# Altium schematic sheet Solenoid Interface.SchDoc("Solenoid Interface")
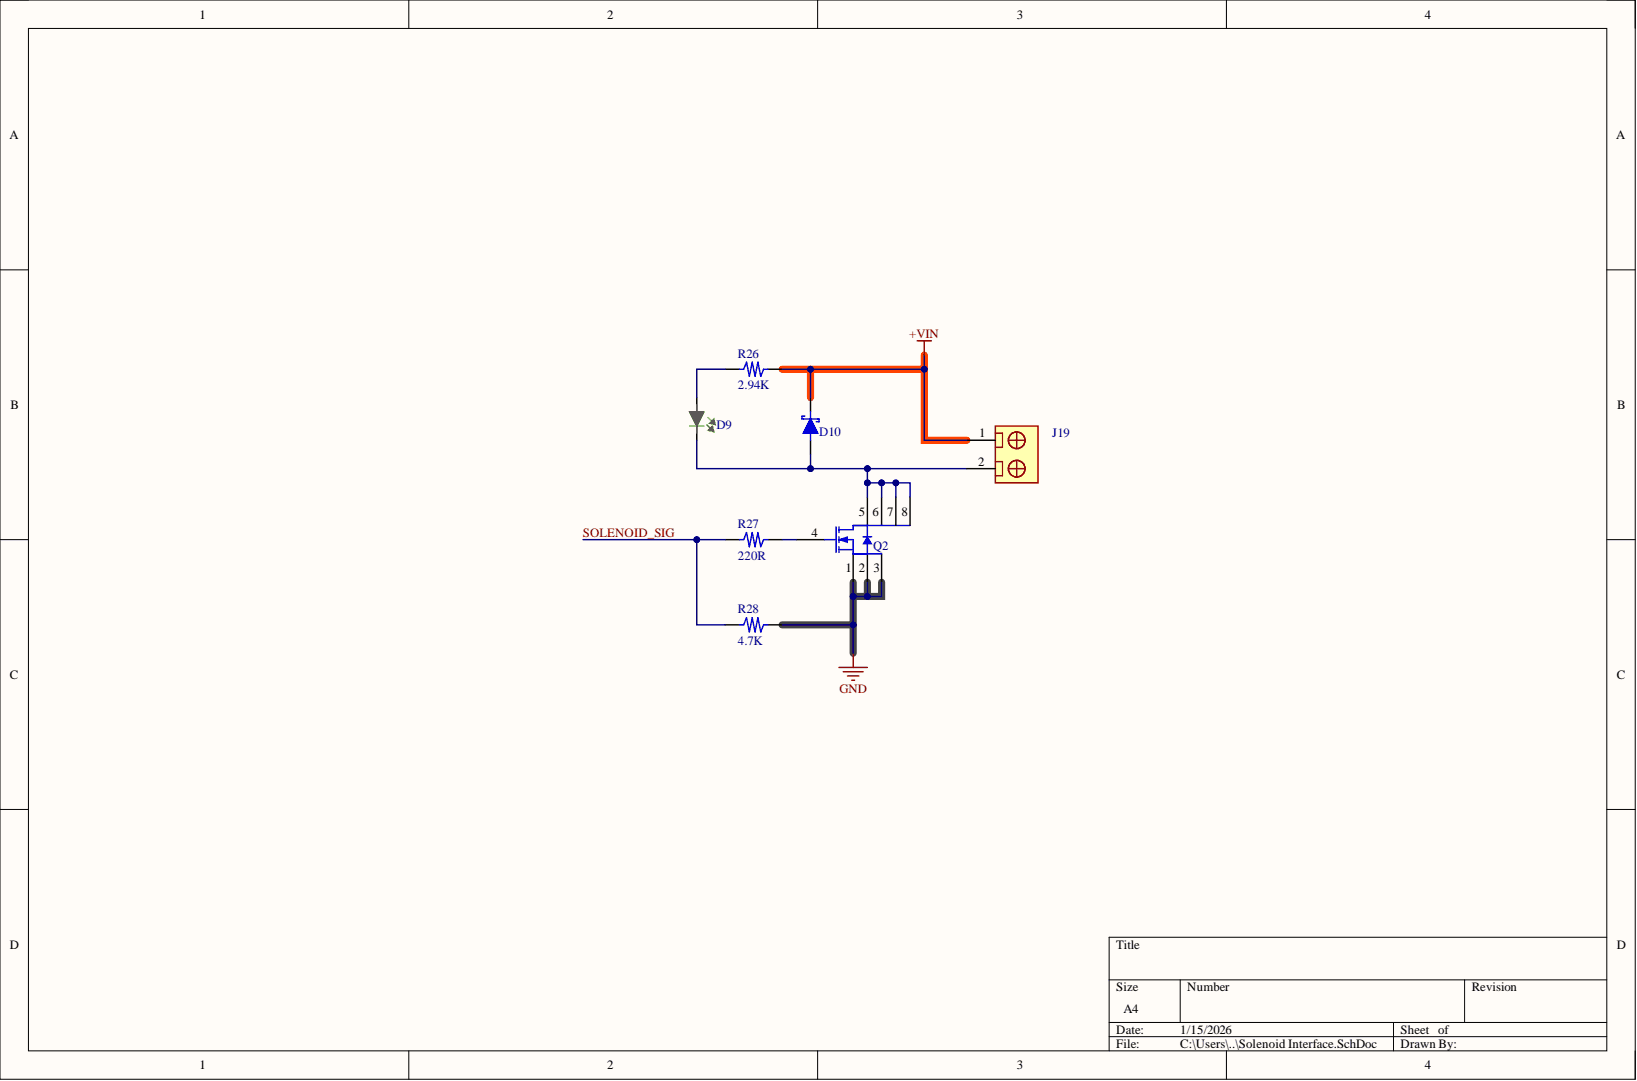
[diagram p0: Solenoid Interface.SchDoc]

## Parts
D9  LG R971-KN-1-0-20-R18  [LED0805]  (value not drawn)
D10  B340AE-13  [SMA(DO-214AC)]  (value not drawn)
J19  1935161  [1935161]  (value not drawn)
Q2  DMT6016LSS-13  [SO-8]  (value not drawn)
R26  value 2.94K  [RES0603]  (hidden comment: RC0603FR-072K94L)
R27  value 220R  [RES0603]  (hidden comment: RC0603FR-07220RL)
R28  value 4.7K  [RES0603]  (hidden comment: RC0603FR-074K7L)

## Nets
+VIN: D10.K J19.1 R26.1
GND: Q2.1 Q2.2 Q2.3 R28.2
SOLENOID_SIG: R27.2 R28.1
NetD9_A: D9.A R26.2
NetD9_K: D9.K D10.A J19.2 Q2.5 Q2.6 Q2.7 Q2.8
NetQ2_4: Q2.4 R27.1

## Library data of the parts
D9 = LG R971-KN-1-0-20-R18: Green 570nm LED Indication - Discrete 2.2V 0805 (2012 Metric); Manufacturer: ams-OSRAM USA INC.; Component Kind: Standard; ComponentLink1Description: Datasheet; ComponentLink1URL: https://look.ams-osram.com/m/2da6f2ceeebf8fac/original/LG-R971.pdf; ComponentLink2Description: DigiKey Link; ComponentLink2URL: https://www.digikey.com/en/products/detail/ams-osram-usa-inc/LG-R971-KN-1-0-20-R18/1227925?s=N4IgTCBcDaIDIHEAEAlAnAdgIwFoDSAcjrgAw5hkpYAcIAugL5A; ComponentLink3Description: LCSC Link; ComponentLink3URL: https://lcsc.com/product-detail/LED-Indication-Discrete_OSRAM-LG-R971-KN-1-0-20-R18_C2899888.html?s_z=n_LG%2520R971-KN-1-0-20-R18; DigiKey PN: 475-LGR971-KN-1CT-ND; LCSC PN: C2899888; Library Name: 05-Optoelectronics.IntLib; MPN: LG R971-KN-1-0-20-R18; Package: 0805
D10 = B340AE-13: DIODE SCHOTTKY 40V 3A SMA; Manufacturer: Diodes Incorporated; Component Kind: Standard; ComponentLink1Description: Datasheet; ComponentLink1URL: https://www.diodes.com/assets/Datasheets/B320AE/B340AE.pdf; ComponentLink2Description: DigiKey Link; ComponentLink2URL: https://www.digikey.com/en/products/detail/diodes-incorporated/B340AE-13/7352831; ComponentLink3Description: LCSC Link; ComponentLink3URL: https://lcsc.com/product-detail/Schottky-Diodes_Diodes-Incorporated-B340AE-13_C168859.html?s_z=n_B340AE-13; DigiKey PN: B340AE-13DICT-ND; LCSC PN: C168859; Library Name: 02-Diodes.IntLib; MPN: B340AE-13; Package: SMA(DO-214AC)
J19 = 1935161: TB 5.00mm 2POS TH 18A, 300V, 12-26 AWG Wire; Manufacturer: Phoenix Contact; Component Kind: Standard; ComponentLink1Description: Datasheet; ComponentLink1URL: https://mm.digikey.com/Volume0/opasdata/d220001/medias/docus/909/1935161.pdf; ComponentLink2Description: DigiKey Link; ComponentLink2URL: https://www.digikey.com/en/products/detail/phoenix-contact/1935161/568614; ComponentLink3Description: LCSC Link; ComponentLink3URL: https://www.lcsc.com/product-detail/C3819953.html?s_z=n_1935161; DigiKey PN: 277-1667-ND; LCSC PN: C3819953; Library Name: 11-Connectors_5.00mm.IntLib; MPN: 1935161; Series: COMBICON PT
Q2 = DMT6016LSS-13: MOSFET N-CH 60V 9.2A SO-8; Manufacturer: Diodes Incorporated; Component Kind: Standard; ComponentLink1Description: Datasheet; ComponentLink1URL: https://www.diodes.com/assets/Datasheets/DMT6016LSS.pdf; ComponentLink2Description: DigiKey Link; ComponentLink2URL: https://www.digikey.com/en/products/detail/diodes-incorporated/DMT6016LSS-13/4967042; ComponentLink3Description: LCSC Link; ComponentLink3URL: https://www.lcsc.com/product-detail/C151586.html?s_z=n_DMT6016LSS-13; DigiKey PN: DMT6016LSS-13DICT-ND; LCSC PN: C151586; Library Name: 03-Transistors_MOSFETS.IntLib; MPN: DMT6016LSS-13; Package: SO-8
R26 = RC0603FR-072K94L: 2.94 kOhms ±1% 0.1W, 1/10W Chip Resistor 0603 (1608 Metric) Moisture Resistant Thick Film; Manufacturer: YAGEO; Component Kind: Standard; ComponentLink1Description: Datasheet; ComponentLink1URL: https://www.yageo.com/upload/media/product/products/datasheet/rchip/PYu-RC_Group_51_RoHS_L_12.pdf; ComponentLink2Description: DigiKey Link; ComponentLink2URL: https://www.digikey.com/en/products/detail/yageo/RC0603FR-072K94L/727037?s=N4IgTCBcDaIE4GMAMA2JBmAZnAtEg7GANYCcALADYgC6AvkA; ComponentLink3Description: LCSC Link; ComponentLink3URL: https://lcsc.com/product-detail/Chip-Resistor-Surface-Mount_YAGEO-RC0603FR-072K94L_C137748.html?s_z=n_RC0603FR-072K94L; DigiKey PN: 311-2.94KHRCT-ND; LCSC PN: C137748; Library Name: 00-Resistors_0603.IntLib; MPN: RC0603FR-072K94L; Package: 0603
R27 = RC0603FR-07220RL: 220 Ohms ±1% 0.1W, 1/10W Chip Resistor 0603 (1608 Metric) Moisture Resistant Thick Film; Manufacturer: YAGEO; Component Kind: Standard; ComponentLink1Description: Datasheet; ComponentLink1URL: https://www.yageo.com/upload/media/product/products/datasheet/rchip/PYu-RC_Group_51_RoHS_L_12.pdf; ComponentLink2Description: DigiKey Link; ComponentLink2URL: https://www.digikey.com/en/products/detail/yageo/RC0603FR-07220RL/727061?s=N4IgTCBcDaIEoGEAMA2JBmAYnAtEg7GGEnADIgC6AvkA; ComponentLink3Description: LCSC Link; ComponentLink3URL: https://lcsc.com/product-detail/Chip-Resistor-Surface-Mount_YAGEO-RC0603FR-07220RL_C107696.html?s_z=n_RC0603FR-07220RL; DigiKey PN: 311-220HRCT-ND; LCSC PN: C107696; Library Name: 00-Resistors_0603.IntLib; MPN: RC0603FR-07220RL; Package: 0603
R28 = RC0603FR-074K7L: 4.7 kOhms ±1% 0.1W, 1/10W Chip Resistor 0603 (1608 Metric) Moisture Resistant Thick Film; Manufacturer: YAGEO; Component Kind: Standard; ComponentLink1Description: Datasheet; ComponentLink1URL: https://www.yageo.com/upload/media/product/products/datasheet/rchip/PYu-RC_Group_51_RoHS_L_12.pdf; ComponentLink2Description: DigiKey Link; ComponentLink2URL: https://www.digikey.com/en/products/detail/yageo/RC0603FR-074K7L/727212?s=N4IgTCBcDaIEoGEAMA2JBmAYnAtEg7ACwDS%2BAMiALoC%2BQA; ComponentLink3Description: LCSC Link; ComponentLink3URL: https://lcsc.com/product-detail/Chip-Resistor-Surface-Mount_YAGEO-RC0603FR-074K7L_C99782.html?s_z=n_RC0603FR-074K7L; DigiKey PN: 311-4.70KHRCT-ND; LCSC PN: C99782; Library Name: 00-Resistors_0603.IntLib; MPN: RC0603FR-074K7L; Package: 0603
